# Altium schematic sheet Sch4 - Fonte 5V.SchDoc("Sch4 - Fonte 5V")
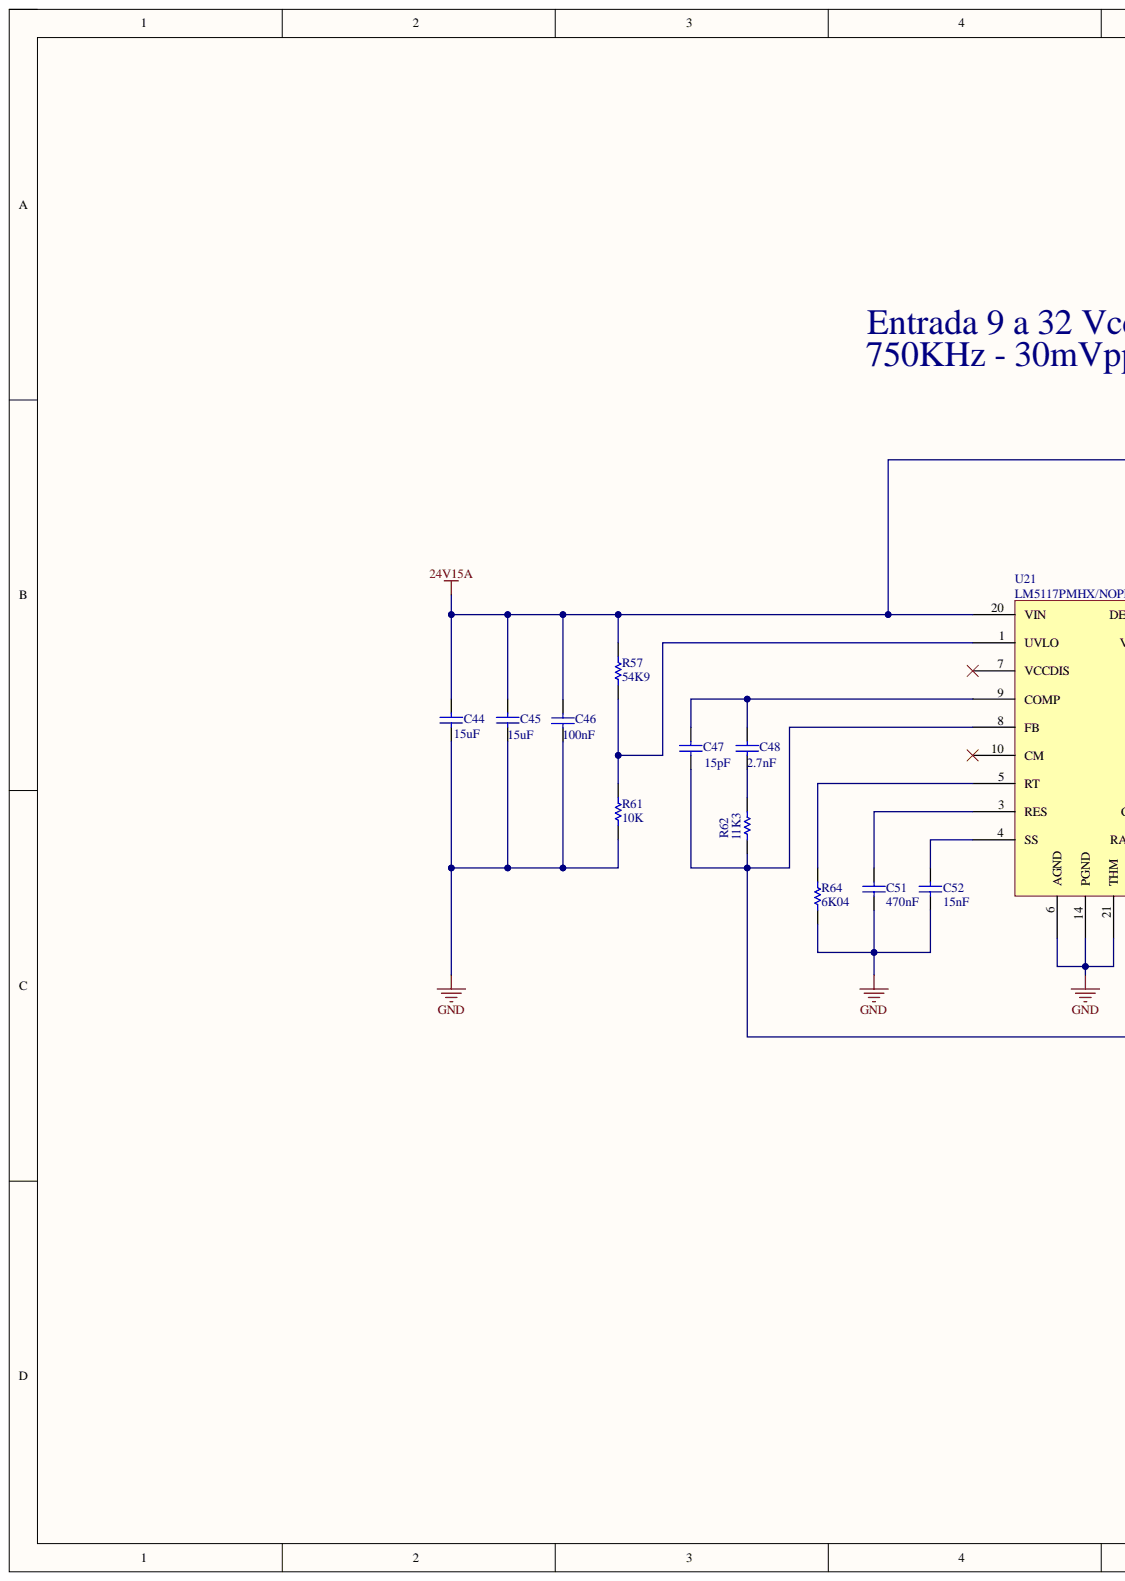
[diagram p0: Sch4 - Fonte 5V.SchDoc, left]
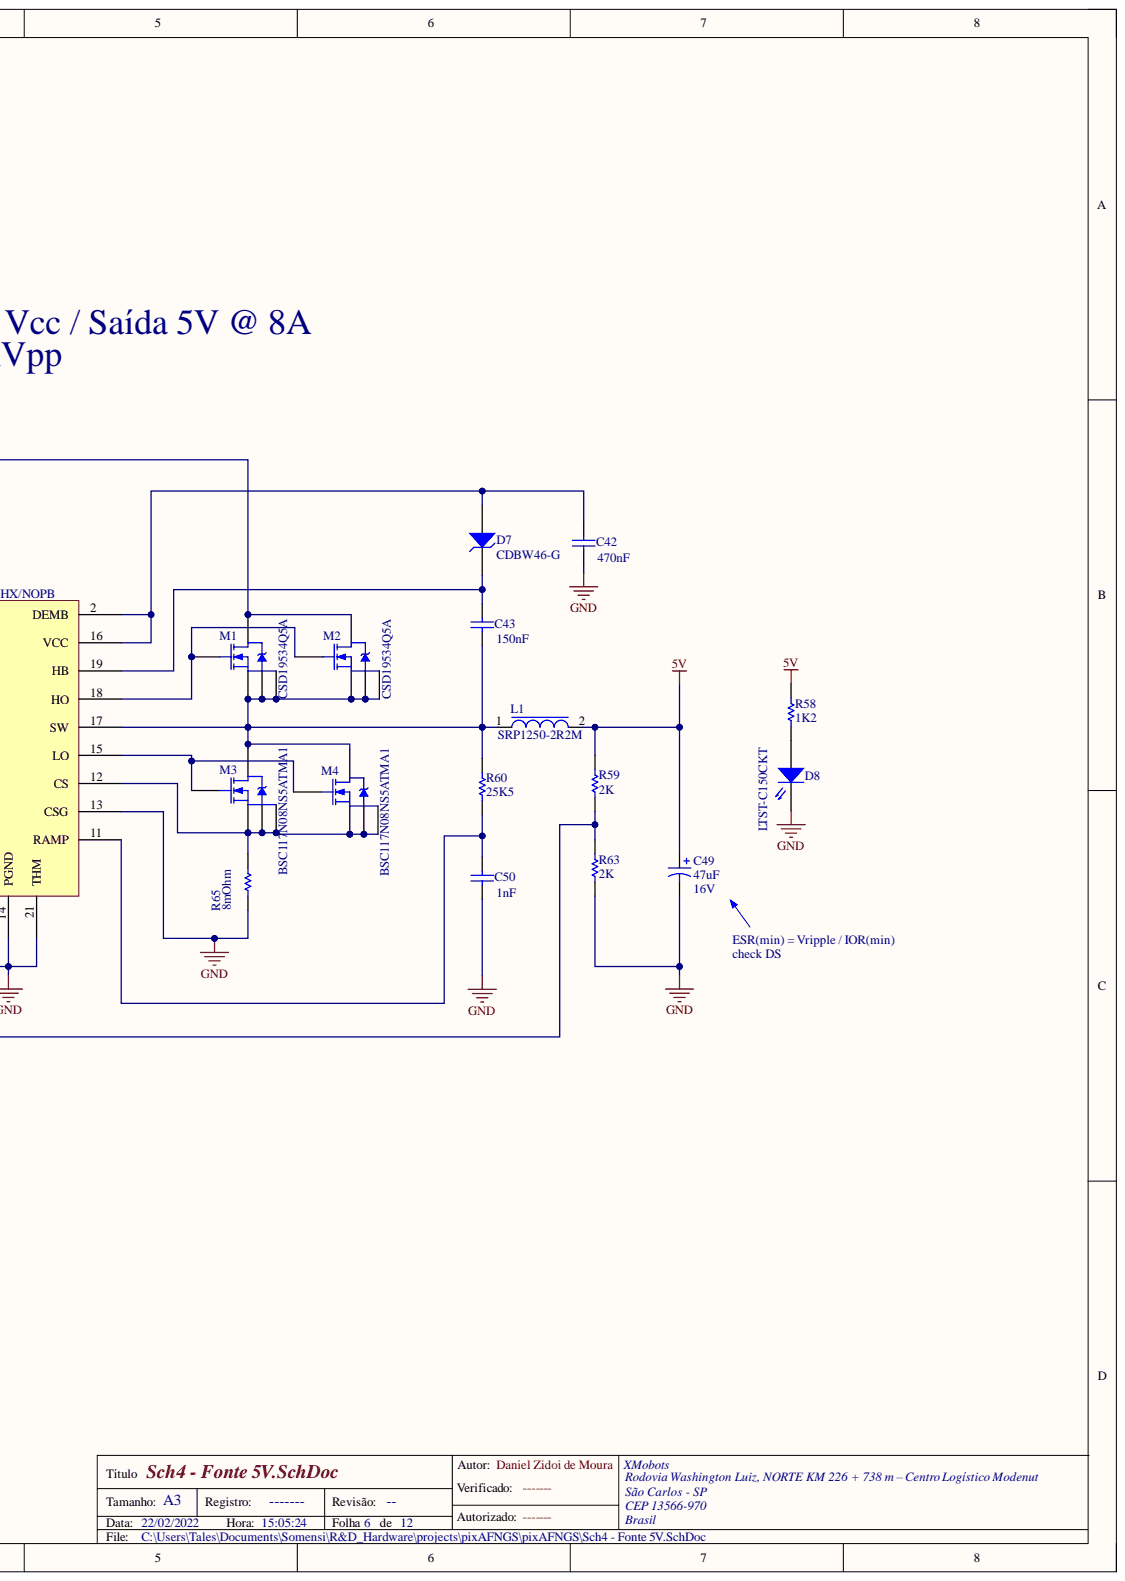
[diagram p1: Sch4 - Fonte 5V.SchDoc, right]

## Parts
C42  GRM188R71A474KA61D: 470nF  [0603]  (hidden comment: Cap Semi)
C43  GRM188R71A154KA01D: 150nF  [0603]  (hidden comment: Cap Semi)
C44  C5750X7S2A156M250KB: 15uF  [5750]  (hidden comment: Cap Semi)
C45  C5750X7S2A156M250KB: 15uF  [5750]  (hidden comment: Cap Semi)
C46  C1608X7S2A104K080AB: 100nF  [0603]  (hidden comment: Cap Semi)
C47  value 15pF  [0603]  (hidden comment: Cap Semi)
C48  value 2.7nF  [0603]  (hidden comment: Cap Semi)
C49  CGA9N3X7R1C476M230KB: 47uF  Voltage: 16V  [5750]  (hidden comment: 35TQC10M)
C50  GRM1885C1H102JA01J: 1nF  [0603]  (hidden comment: Cap Semi)
C51  GRM188R71C474KA88D: 470nF  [0603]  (hidden comment: Cap Semi)
C52  CGA4F2C0G1H153J085AA: 15nF  [0805]  (hidden comment: Cap Semi)
D7  CDBW46-G  [SOD-123]
D8  LTST-C150CKT  [LED 1206]
L1  SRP1250-2R2M  [SRP1250-3R3M]
M1  CSD19534Q5A  [TDSON_8]
M2  CSD19534Q5A  [TDSON_8]
M3  BSC117N08NS5ATMA1  [TDSON_8]
M4  BSC117N08NS5ATMA1  [TDSON_8]
R57  CRCW060354K9FKEA: 54K9  [0603]  (hidden comment: Res Semi)
R58  value 1K2  [0603]  (hidden comment: Res Semi)
R59  RC0603FR-075K6L: 2K  [0603]  (hidden comment: Res Semi)
R60  value 25K5  [1206]  (hidden comment: Res Semi)
R61  CRCW06032K32FKEA: 10K  [0603]  (hidden comment: Res Semi)
R62  value 11K3  [0603]  (hidden comment: Res Semi)
R63  RC0603FR-07953RL: 2K  [0603]  (hidden comment: Res Semi)
R64  CRCW06036K04FKEA: 6K04  [0603]  (hidden comment: Res Semi)
R65  value 8mOhm  [1206]  (hidden comment: Res Semi)
U21  LM5117PMHX/NOPB  [HTSSOP-20]

## Nets
5V: C49.1 L1.2 R58.1 R59.1
24V15A: C44.2 C45.2 C46.2 M1.5 M2.5 R57.2 U21.20(VIN)
GND: C42.1 C44.1 C45.1 C46.1 C49.2 C50.1 C51.1 C52.2 D8.2 R61.1 R63.2 R64.1 R65.1 U21.6(AGND) U21.13(CSG) U21.14(PGND) U21.21(THM)
NetC42_2: C42.2 D7.1 U21.2(DEMB) U21.16(VCC)
NetC43_1: C43.1 L1.1 M1.1 M1.2 M1.3 M2.1 M2.2 M2.3 M3.5 M4.5 R60.2 U21.17(SW)
NetC43_2: C43.2 D7.2 U21.19(HB)
NetC47_1: C47.1 R59.2 R62.1 R63.1 U21.8(FB)
NetC47_2: C47.2 C48.2 U21.9(COMP)
NetC48_1: C48.1 R62.2
NetC50_2: C50.2 R60.1 U21.11(RAMP)
NetC51_2: C51.2 U21.3(RES)
NetC52_1: C52.1 U21.4(SS)
NetD8_1: D8.1 R58.2
NetM1_4: M1.4 M2.4 U21.18(HO)
NetM3_1: M3.1 M3.2 M3.3 M4.1 M4.2 M4.3 R65.2 U21.12(CS)
NetM3_4: M3.4 M4.4 U21.15(LO)
NetR57_1: R57.1 R61.2 U21.1(UVLO)
NetR64_2: R64.2 U21.5(RT)

Not connected: U21 7(VCCDIS) 10(CM)

## Library data of the parts
C42-C46 C50-C52 = Cap Semi: Capacitor (Semiconductor SIM Model); Component Kind: Standard; Library Name: Miscellaneous Devices.IntLib; PackageReference: C1206; Published: 8-Jun-2000; Signal Integrity: Cap Semi; Simulation: CAP; Publisher: Altium Limited
  C42 C43 C50-C52: LatestRevisionDate: 17-Jul-2002; LatestRevisionNote: Re-released for DXP Platform.
  C44-C46: LatestRevisionDate: 29-May-2009; LatestRevisionNote: IPC-7351 Footprint Added.; PackageDescription: Chip Capacitor
C47 = Cap Semi: Capacitor (Semiconductor SIM Model); Part Number: GCM1885C1H150JA16D; Component Kind: Standard; LatestRevisionDate: 17-Jul-2002; LatestRevisionNote: Re-released for DXP Platform.; Library Name: Miscellaneous Devices.IntLib; PackageReference: C1206; Published: 8-Jun-2000; Signal Integrity: Cap Semi; Simulation: CAP; Publisher: Altium Limited
C48 = Cap Semi: Capacitor (Semiconductor SIM Model); Part Number: CL10C332JB8NFNC; Component Kind: Standard; LatestRevisionDate: 17-Jul-2002; LatestRevisionNote: Re-released for DXP Platform.; Library Name: Miscellaneous Devices.IntLib; PackageReference: C1206; Published: 8-Jun-2000; Signal Integrity: Cap Semi; Simulation: CAP; Publisher: Altium Limited
C49 = Cap Pol1: Polarized Capacitor (Radial); Component Kind: Standard; LatestRevisionDate: 17-Jul-2002; LatestRevisionNote: Re-released for DXP Platform.; Library Name: Miscellaneous Devices.IntLib; PackageReference: RB7.6-15; Published: 8-Jun-2000; Signal Integrity: Cap Pol; Simulation: CAP; Publisher: Altium Limited
D7 = BAS316-TP: DIODE 100V 500MA SOD323; Component Kind: Standard; Supplier 1: Digi-Key; Supplier Part Number 1: BAS316-TPMSCT-ND; Library Name: XM_Diodes.SchLib
D8 = LTST-C150CKT: LED RED CLEAR 1206 SMD; Component Kind: Standard; Supplier 1: Digi-Key; Supplier Part Number 1: 160-1167-1-ND; Library Name: XM_Diodes.SchLib
L1 = 74435588200: FIXED IND 82UH 7A 30.4 MOHM SMD; Component Kind: Standard; Supplier 1: Digi-Key; Supplier Part Number 1: 732-4241-1-ND; Library Name: XM_Inductor.SchLib
M1-M4 = BSC117N08NS5ATMA1: MOSFET N-CH 80V 49A Automotive; Component Kind: Standard; Library Name: XM_Fet.SchLib
R57-R59 R61 R63 R64 = Res Semi: Semiconductor Resistor; Component Kind: Standard; LatestRevisionDate: 17-Jul-2002; LatestRevisionNote: Re-released for DXP Platform.; Library Name: Miscellaneous Devices.IntLib; PackageReference: AXIAL-0.5; Published: 8-Jun-2000; Signal Integrity: Res Semi; Simulation: RES; Publisher: Altium Limited
R60 = Res Semi: Semiconductor Resistor; Part Number: CRCW120628K0FKEA; Component Kind: Standard; LatestRevisionDate: 17-Jul-2002; LatestRevisionNote: Re-released for DXP Platform.; Library Name: Miscellaneous Devices.IntLib; PackageReference: AXIAL-0.5; Published: 8-Jun-2000; Signal Integrity: Res Semi; Simulation: RES; Publisher: Altium Limited
R62 = Res Semi: Semiconductor Resistor; Part Number: RC0603FR-0710K2L; Component Kind: Standard; LatestRevisionDate: 17-Jul-2002; LatestRevisionNote: Re-released for DXP Platform.; Library Name: Miscellaneous Devices.IntLib; PackageReference: AXIAL-0.5; Published: 8-Jun-2000; Signal Integrity: Res Semi; Simulation: RES; Publisher: Altium Limited
R65 = Res Semi: Semiconductor Resistor; Part Number: WSLP12068L000FEA; Component Kind: Standard; LatestRevisionDate: 17-Jul-2002; LatestRevisionNote: Re-released for DXP Platform.; Library Name: Miscellaneous Devices.IntLib; PackageReference: AXIAL-0.5; Published: 8-Jun-2000; Signal Integrity: Res Semi; Simulation: RES; Publisher: Altium Limited
U21 = LM5117PMHX/NOPB: Component Kind: Standard; Library Name: XM_IC.SchLib
